# Altium schematic sheet TopLevel.SchDoc
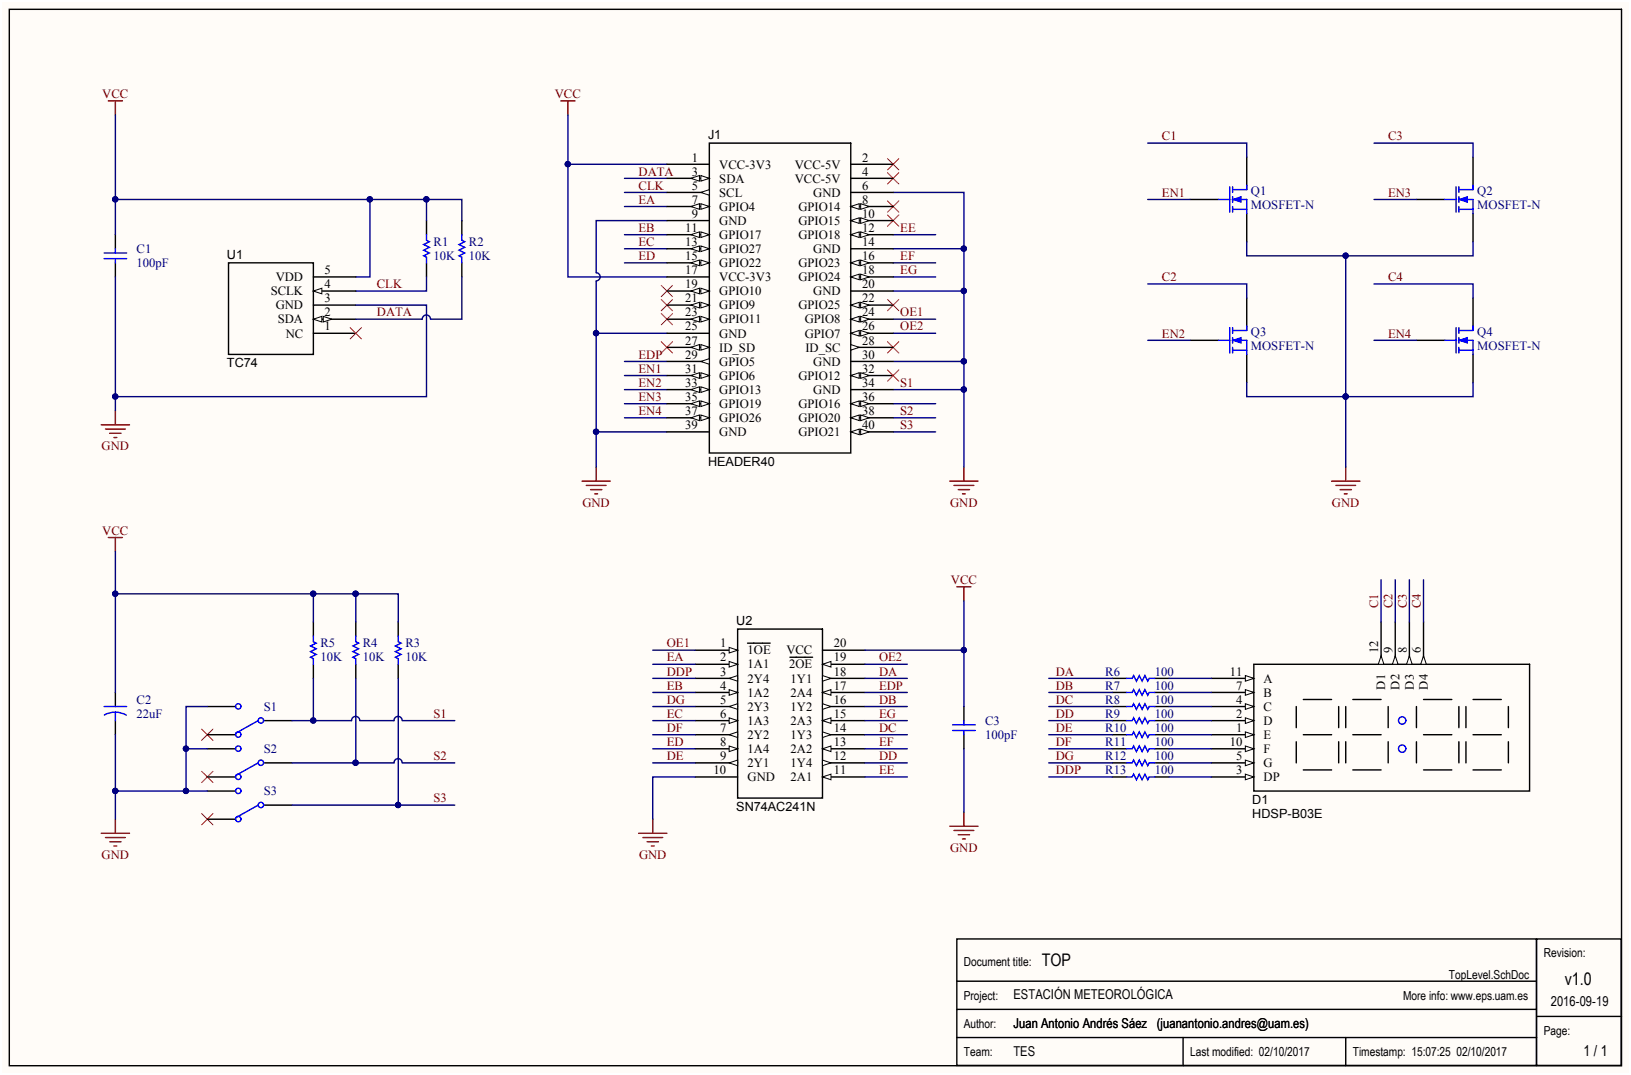
[diagram p0: TopLevel.SchDoc]

## Parts
C1  value 100pF  [RAD-0.3]  (hidden comment: Cap)
C2  value 22uF  [CAPR5-4X5]  (hidden comment: Cap2)
C3  value 100pF  [RAD-0.3]  (hidden comment: Cap)
D1  HDSP-B03E  [7SEGDISPx4]
J1  HEADER40
Q1  MOSFET-N  [TO-92]
Q2  MOSFET-N  [TO-92]
Q3  MOSFET-N  [TO-92]
Q4  MOSFET-N  [TO-92]
R1  value 10K  [AXIAL-0.3]  (hidden comment: Res1)
R2  value 10K  [AXIAL-0.3]  (hidden comment: Res1)
R3  value 10K  [AXIAL-0.3]  (hidden comment: Res1)
R4  value 10K  [AXIAL-0.3]  (hidden comment: Res1)
R5  value 10K  [AXIAL-0.3]  (hidden comment: Res1)
R6  value 100  [AXIAL-0.3]  (hidden comment: Res1)
R7  value 100  [AXIAL-0.3]  (hidden comment: Res1)
R8  value 100  [AXIAL-0.3]  (hidden comment: Res1)
R9  value 100  [AXIAL-0.3]  (hidden comment: Res1)
R10  value 100  [AXIAL-0.3]  (hidden comment: Res1)
R11  value 100  [AXIAL-0.3]  (hidden comment: Res1)
R12  value 100  [AXIAL-0.3]  (hidden comment: Res1)
R13  value 100  [AXIAL-0.3]  (hidden comment: Res1)
S1  SW DPDT  [2PSWITCH]  (value not drawn)
S2  SW DPDT  [2PSWITCH]  (value not drawn)
S3  SW DPDT  [2PSWITCH]  (value not drawn)
U1  TC74
U2  SN74AC241N  [DIP-20]

## Nets
VCC: C1.2 C2.1 C3.2 J1.1(VCC-3V3) J1.17(VCC-3V3) R1.2 R2.2 R3.2 R4.2 R5.2 U1.5(VDD) U2.20(VCC)
C1: D1.12 Q1.1
C2: D1.9(D2) Q3.1
C3: D1.8(D3) Q2.1
C4: D1.6(D4) Q4.1
CLK: J1.5(SCL) R1.1 U1.4(SCLK)
DA: R6.1 U2.18(1Y1)
DATA: J1.3(SDA) R2.1 U1.2(SDA)
DB: R7.1 U2.16(1Y2)
DC: R8.1 U2.14(1Y3)
DD: R9.1 U2.12(1Y4)
DDP: R13.1 U2.3(2Y4)
DE: R10.1 U2.9(2Y1)
DF: R11.1 U2.7(2Y2)
DG: R12.1 U2.5(2Y3)
EA: J1.7(GPIO4) U2.2(1A1)
EB: J1.11(GPIO17) U2.4(1A2)
EC: J1.13(GPIO27) U2.6(1A3)
ED: J1.15(GPIO22) U2.8(1A4)
EDP: J1.29(GPIO5) U2.17(2A4)
EE: J1.12(GPIO18) U2.11(2A1)
EF: J1.16(GPIO23) U2.13(2A2)
EG: J1.18(GPIO24) U2.15(2A3)
EN1: J1.31(GPIO6) Q1.2
EN2: J1.33(GPIO13) Q3.2
EN3: J1.35(GPIO19) Q2.2
EN4: J1.37(GPIO26) Q4.2
OE1: J1.24(GPIO8) U2.1(1OE)
OE2: J1.26(GPIO7) U2.19(2OE)
S1: C1.1 C2.2 C3.1 J1.6(GND) J1.9(GND) J1.14(GND) J1.20(GND) J1.25(GND) J1.30(GND) J1.34(GND) J1.39(GND) Q1.3 Q2.3 Q3.3 Q4.3 R5.1 S1.2 S1.3 S2.3 S3.3 U1.3(GND) U2.10(GND)
S2: J1.38(GPIO20) R4.1 S2.2
S3: J1.40(GPIO21) R3.1 S3.2
NetD1_1: D1.1(E) R10.2
NetD1_2: D1.2(D) R9.2
NetD1_3: D1.3(DP) R13.2
NetD1_4: D1.4(C) R8.2
NetD1_5: D1.5(G) R12.2
NetD1_7: D1.7(B) R7.2
NetD1_10: D1.10(F) R11.2
NetD1_11: D1.11(A) R6.2

Not connected: J1 2(VCC-5V) 4(VCC-5V) 8(GPIO14) 10(GPIO15) 19(GPIO10) 21(GPIO9) 22(GPIO25) 23(GPIO11) 27(ID_SD) 28(ID_SC) 32(GPIO12) 36(GPIO16); S1 1; S2 1; S3 1; U1 1(NC)

## Library data of the parts
C1 C3 = Cap: Capacitor; Component Kind: Standard; LatestRevisionDate: 17-Jul-2002; LatestRevisionNote: Re-released for DXP Platform.; Library Name: 7SEG_CTRL.SchLib; PackageDescription: Radial Cap, Thru-Hole; 2 Leads; 0.3 in Pin Spacing; PackageReference: RAD-0.3; Published: 8-Jun-2000; Signal Integrity: Cap; Simulation: CAP; Publisher: Altium Limited
C2 = Cap2: Capacitor; Component Kind: Standard; Library Name: LED_CTRL.SchLib; PackageDescription: Capacitor; 2 Leads; PackageReference: CAPR5-4X5; Published: 23-Sep-2002; Signal Integrity: Cap; Simulation: CAP; Publisher: Altium Limited
D1 = HDSP-B03E: 4 7-Segment Display Common Cathode; Component Kind: Standard; Library Name: 7SEG_CTRL.SchLib
J1 = OPICON3: Orange PI CON3 Header; Component Kind: Standard; Library Name: 7SEG_CTRL.SchLib
Q1-Q4 = MOSFET-N: N-Channel MOSFET; Component Kind: Standard; LatestRevisionDate: 17-Jul-2002; LatestRevisionNote: Re-released for DXP Platform.; Library Name: 7SEG_CTRL.SchLib; PackageDescription: TO, Flat Index; 3 In-Line, Axial Leads; Body Dia. 4.6mm; Lead Dia. 0.5 mm (max); PackageReference: E3; PackageVersion: 1995/1996; Published: 8-Jun-2000; Simulation: NMOS; Publisher: Altium Limited
R1-R13 = Res1: Resistor; Component Kind: Standard; LatestRevisionDate: 17-Jul-2002; LatestRevisionNote: Re-released for DXP Platform.; Library Name: LED_CTRL.SchLib; PackageDescription: Axial Device, Thru-Hole; 2 Leads; 0.3 in Pin Spacing; PackageReference: AXIAL-0.3; Published: 8-Jun-2000; Signal Integrity: Res; Simulation: RESISTOR; Publisher: Altium Limited
S1-S3 = SW DPDT: Switch; Code_IPC: SOT26A-6; Code_JEITA: SC-74; Component Kind: Standard; LatestRevisionDate: 29-May-2009; LatestRevisionNote: IPC-7351 Footprint Added.; Library Name: 7SEG_CTRL.SchLib; PackageDescription: 6-Pin SOT-23 Package 0.95 mm Pitch, 2.7 mm Lead Span; PackageReference: SOT23-6; PackageVersion: Mar-2001; Published: 24-Mar-1999; Publisher: Altium Limited
U1 = TC74: Temperature Sensor; Component Kind: Standard; Library Name: 7SEG_CTRL.SchLib
U2 = SN74AC241N: Octal 3-state Buffer/Driver; Component Kind: Standard; Library Name: 7SEG_CTRL.SchLib
